# Altium schematic sheet switches.SchDoc
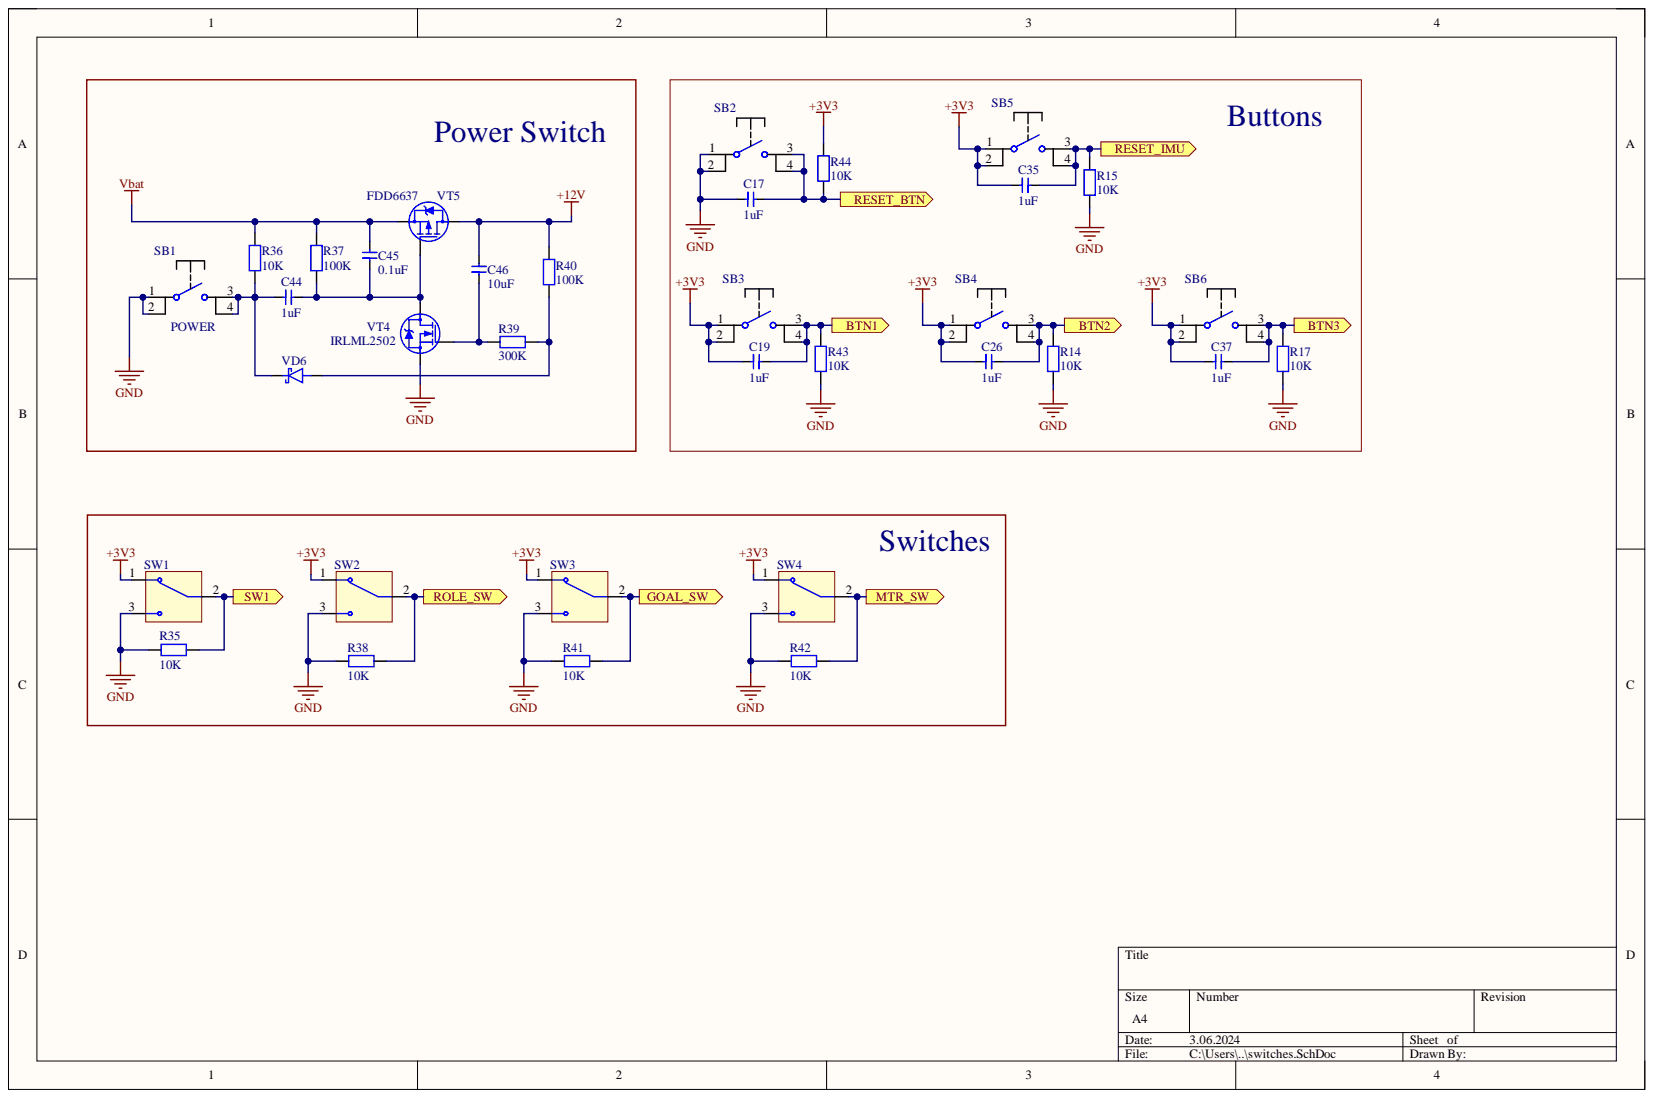
[diagram p0: switches.SchDoc]

## Parts
C17  1uF  [CAP0805]
C19  1uF  [CAP0805]
C26  1uF  [CAP0805]
C35  1uF  [CAP0805]
C37  1uF  [CAP0805]
C44  1uF  [CAP0805]
C45  0.1uF  [CAP0805]
C46  10uF  [CAP0805]
R14  10K  [RES0805]
R15  10K  [RES0805]
R17  10K  [RES0805]
R35  10K  [RES0805]
R36  10K  [RES0805]
R37  100K  [RES0805]
R38  10K  [RES0805]
R39  300K  [RES0805]
R40  100K  [RES0805]
R41  10K  [RES0805]
R42  10K  [RES0805]
R43  10K  [RES0805]
R44  10K  [RES0805]
SB1  POWER  [DTSM-61N-V-T/R]
SB2  DTSM-61N-V-T/R  [DTSM-61N-V-T/R]  (value not drawn)
SB3  DTSM-61N-V-T/R  [DTSM-61N-V-T/R]  (value not drawn)
SB4  DTSM-61N-V-T/R  [DTSM-61N-V-T/R]  (value not drawn)
SB5  DTSM-61N-V-T/R  [DTSM-61N-V-T/R]  (value not drawn)
SB6  DTSM-61N-V-T/R  [DTSM-61N-V-T/R]  (value not drawn)
SW1  ESP1010  [ESP1010]  (value not drawn)
SW2  ESP1010  [ESP1010]  (value not drawn)
SW3  ESP1010  [ESP1010]  (value not drawn)
SW4  ESP1010  [ESP1010]  (value not drawn)
VD6  (no value)  [DO-214AC (SMA)]
VT4  IRLML2502  [SOT-23-3]
VT5  FDD6637  [DPAK]

## Ports
BTN1, BTN2, BTN3, GOAL_SW, MTR_SW, RESET_BTN, RESET_IMU, ROLE_SW, SW1

## Nets
+3V3: C19.1 C26.1 C35.1 C37.1 R44.2 SB3.1 SB3.2 SB4.1 SB4.2 SB5.1 SB5.2 SB6.1 SB6.2 SW1.1 SW2.1 SW3.1 SW4.1
+12V: C46.2 R40.2 VT5.D
GND: C17.1 R14.1 R15.1 R17.1 R35.1 R38.1 R41.1 R42.1 R43.1 SB1.1 SB1.2 SB2.1 SB2.2 SW1.3 SW2.3 SW3.3 SW4.3 VT4.S
Vbat: C45.2 R36.1 R37.1 VT5.S
BTN1: C19.2 R43.2 SB3.3 SB3.4(C19) ; port BTN1
BTN2: C26.2 R14.2 SB4.3 SB4.4(C26) ; port BTN2
BTN3: C37.2 R17.2 SB6.3 SB6.4(C37) ; port BTN3
GOAL_SW: R41.2 SW3.2 ; port GOAL_SW
MTR_SW: R42.2 SW4.2 ; port MTR_SW
RESET_BTN: C17.2 R44.1 SB2.3 SB2.4 ; port RESET_BTN
RESET_IMU: C35.2 R15.2 SB5.3 SB5.4 ; port RESET_IMU
ROLE_SW: R38.2 SW2.2 ; port ROLE_SW
SW1: R35.2 SW1.2 ; port SW1
NetC44_1: C44.1 C45.1 R37.2 VT4.D VT5.G
NetC44_2: C44.2 R36.2 SB1.3 SB1.4 VD6.K
NetC46_1: C46.1 R39.2 VT4.G
NetR39_1: R39.1 R40.1 VD6.A

## Library data of the parts
C17 C19 C26 C35 C37 C44-C46 = CAP Ceramic: Ceramic SMD Cap; Component Kind: Standard; Library Name: Asterisk.SchLib
R14 R15 R17 R35-R44 = RES: RES smd; Component Kind: Standard; Library Name: Asterisk.SchLib
SB1 = Button: Component Kind: Standard; Description: Button; Library Name: Asterisk.SchLib
SB2 = Button: RESET; Component Kind: Standard; Library Name: Asterisk.SchLib
SB3 = Button: BTN1; Component Kind: Standard; Library Name: Asterisk.SchLib
SB4 = Button: BTN2; Component Kind: Standard; Library Name: Asterisk.SchLib
SB5 = Button: RESET_IMU; Component Kind: Standard; Library Name: Asterisk.SchLib
SB6 = Button: BTN3; Component Kind: Standard; Library Name: Asterisk.SchLib
SW1 = ESP1010: SW1; Component Kind: Standard; ComponentLink1Description: Chipdip; ComponentLink1URL: https://www.chipdip.ru/product0/8007034319; Library Name: Asterisk.SchLib
SW2 = ESP1010: Role; Component Kind: Standard; ComponentLink1Description: Chipdip; ComponentLink1URL: https://www.chipdip.ru/product0/8007034319; Library Name: Asterisk.SchLib
SW3 = ESP1010: Goal; Component Kind: Standard; ComponentLink1Description: Chipdip; ComponentLink1URL: https://www.chipdip.ru/product0/8007034319; Library Name: Asterisk.SchLib
SW4 = ESP1010: Motor; Component Kind: Standard; ComponentLink1Description: Chipdip; ComponentLink1URL: https://www.chipdip.ru/product0/8007034319; Library Name: Asterisk.SchLib
VD6 = Diode Schottky: Component Kind: Standard; Description: Diode Schottky; Library Name: Asterisk.SchLib
VT4 = IRLML2502: N-MOSFET  Uds = 20 V  Ids = 4.2 A  Rds = 0.035 Ohm  P = 8 W; Component Kind: Standard; ComponentLink1Description: Chipdip; ComponentLink1URL: https://www.chipdip.ru/product/irlml2502-umw; Library Name: Asterisk.SchLib
VT5 = FDD6637: P-MOSFET  Uds = 40 V  Ids = 40 A  Rds = 0.012 Ohm  P = 3.0 W; Component Kind: Standard; ComponentLink1Description: Chipdip; ComponentLink1URL: https://www.chipdip.ru/product/fdd6637-vbsemi; Library Name: Asterisk.SchLib
